# Altium schematic sheet E16-02-002.SchDoc(E16-02-002)
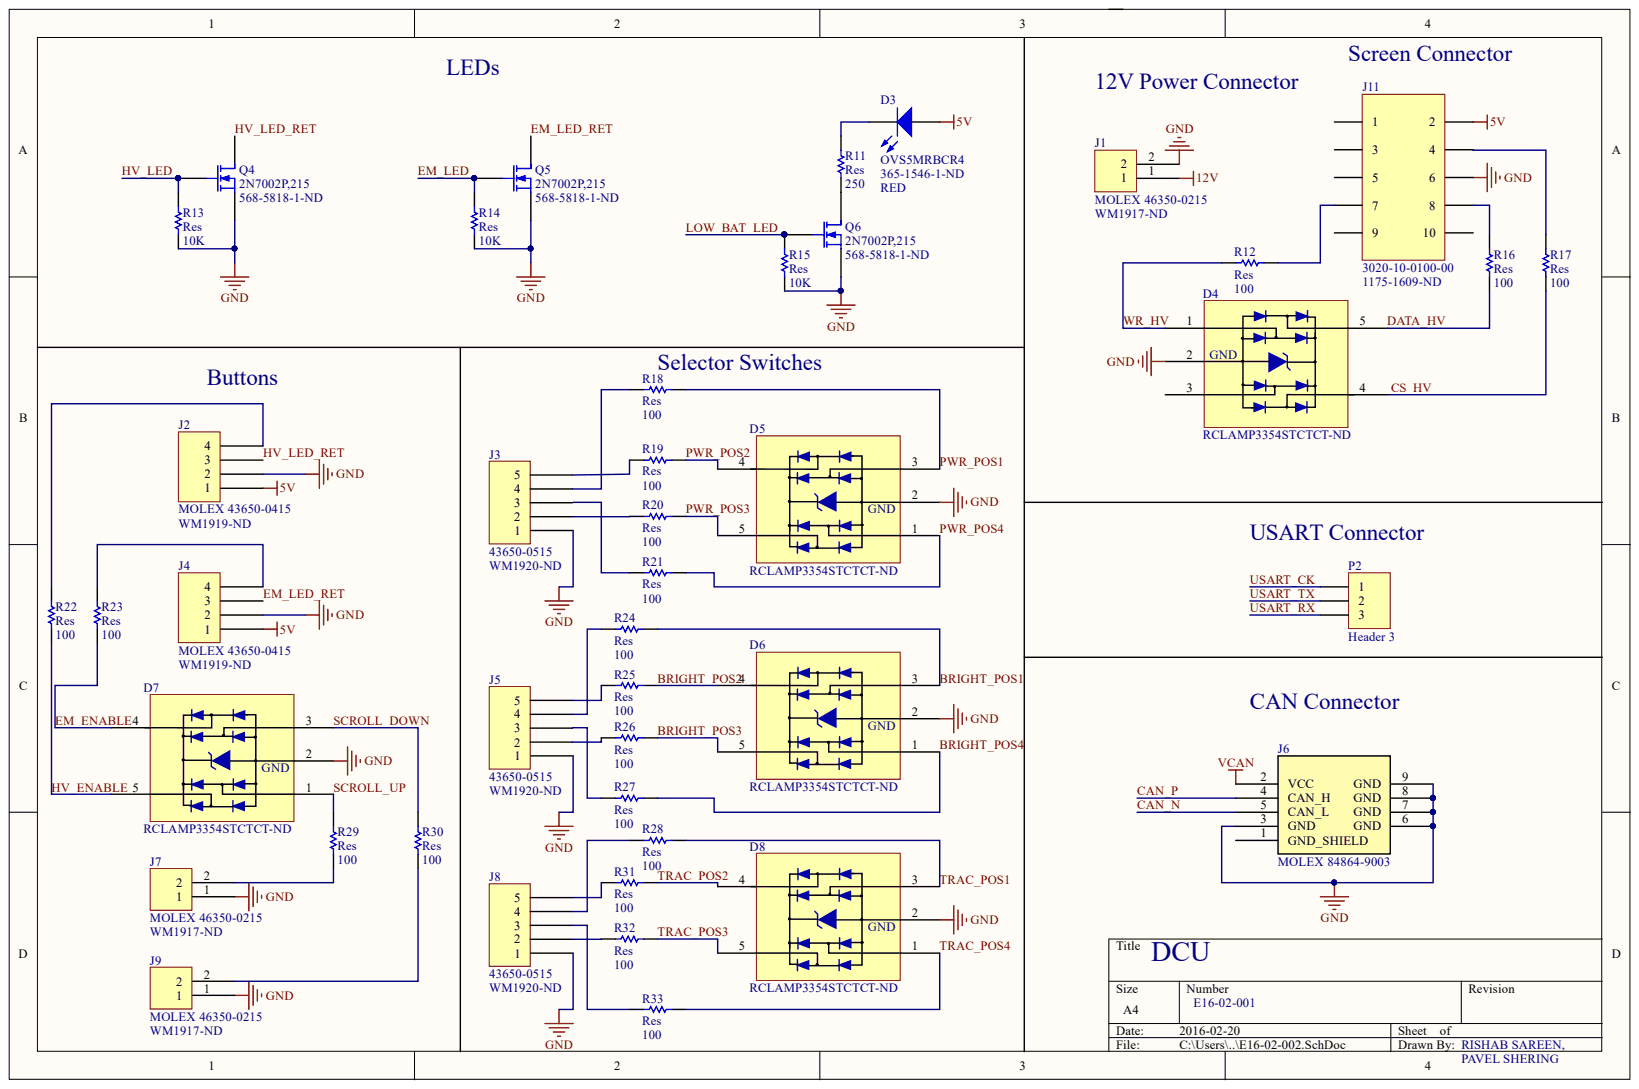
[diagram p0: E16-02-002.SchDoc]

## Parts
D3  OVS5MRBCR4  Supplier Part Number 1: 365-1546-1-ND  [OVS5MRBCR4]
D4  RCLAMP3354S.TCT  Supplier Part Number 1: RCLAMP3354STCTCT-ND  [SOT95P280X145-5N]
D5  RCLAMP3354S.TCT  Supplier Part Number 1: RCLAMP3354STCTCT-ND  [SOT95P280X145-5N]
D6  RCLAMP3354S.TCT  Supplier Part Number 1: RCLAMP3354STCTCT-ND  [SOT95P280X145-5N]
D7  RCLAMP3354S.TCT  Supplier Part Number 1: RCLAMP3354STCTCT-ND  [SOT95P280X145-5N]
D8  RCLAMP3354S.TCT  Supplier Part Number 1: RCLAMP3354STCTCT-ND  [SOT95P280X145-5N]
J1  MOLEX 46350-0215  Supplier Part Number 1: WM1917-ND  [MOLEX 43650-0215]
J2  MOLEX 43650-0415  Supplier Part Number 1: WM1919-ND  [MOLEX 43650-0415]
J3  43650-0515  Supplier Part Number 1: WM1920-ND  [MOLEX 43650-0515]
J4  MOLEX 43650-0415  Supplier Part Number 1: WM1919-ND  [MOLEX 43650-0415]
J5  43650-0515  Supplier Part Number 1: WM1920-ND  [MOLEX 43650-0515]
J6  MOLEX 84864-9003  [MOLEX 84864-9003]
J7  MOLEX 46350-0215  Supplier Part Number 1: WM1917-ND  [MOLEX 43650-0215]
J8  43650-0515  Supplier Part Number 1: WM1920-ND  [MOLEX 43650-0515]
J9  MOLEX 46350-0215  Supplier Part Number 1: WM1917-ND  [MOLEX 43650-0215]
J11  3020-10-0100-00  [IDC 10 Pos]
P2  Header 3  [HDR1X3]
Q4  2N7002P,215  Supplier Part Number 1: 568-5818-1-ND  [SOT23-3]
Q5  2N7002P,215  Supplier Part Number 1: 568-5818-1-ND  [SOT23-3]
Q6  2N7002P,215  Supplier Part Number 1: 568-5818-1-ND  [SOT23-3]
R11  Res  value 250  [3216[1206]]
R12  Res  value 100  [3216[1206]]
R13  Res  value 10K  [3216[1206]]
R14  Res  value 10K  [3216[1206]]
R15  Res  value 10K  [3216[1206]]
R16  Res  value 100  [3216[1206]]
R17  Res  value 100  [3216[1206]]
R18  Res  value 100  [3216[1206]]
R19  Res  [3216[1206]]
R20  Res  value 100  [3216[1206]]
R21  Res  value 100  [3216[1206]]
R22  Res  value 100  [3216[1206]]
R23  Res  value 100  [3216[1206]]
R24  Res  value 100  [3216[1206]]
R25  Res  value 100  [3216[1206]]
R26  Res  value 100  [3216[1206]]
R27  Res  value 100  [3216[1206]]
R28  Res  value 100  [3216[1206]]
R29  Res  value 100  [3216[1206]]
R30  Res  value 100  [3216[1206]]
R31  Res  value 100  [3216[1206]]
R32  Res  value 100  [3216[1206]]
R33  Res  value 100  [3216[1206]]

## Nets
5V: D3.1 J2.1 J4.1 J11.2
12V: J1.1
GND: D4.2 D5.2 D6.2 D7.2 D8.2 J1.2 J2.2 J3.1 J4.2 J5.1 J6.3(GND) J6.6(GND) J6.7(GND) J6.8(GND) J6.9(GND) J7.1 J8.1 J9.1 J11.6 Q4.2 Q5.2 Q6.2 R13.1 R14.1 R15.1
VCAN: J6.2(VCC)
BRIGHT_POS1: D6.3 R24.1
BRIGHT_POS2: D6.4 R25.2
BRIGHT_POS3: D6.5 R26.2
BRIGHT_POS4: D6.1 R27.1
CAN_N: J6.5(CAN_L)
CAN_P: J6.4(CAN_H)
CS_HV: D4.4 R17.1
DATA_HV: D4.5 R16.1
EM_ENABLE: D7.4 R23.1
EM_LED: Q5.1 R14.2
EM_LED_RET: J4.3 Q5.3
HV_ENABLE: D7.5 R22.1
HV_LED: Q4.1 R13.2
HV_LED_RET: J2.3 Q4.3
LOW_BAT_LED: Q6.1 R15.2
PWR_POS1: D5.3 R18.1
PWR_POS2: D5.4 R19.1
PWR_POS3: D5.5 R20.2
PWR_POS4: D5.1 R21.1
SCROLL_DOWN: D7.3 R30.2
SCROLL_UP: D7.1 R29.1
TRAC_POS1: D8.3 R28.1
TRAC_POS2: D8.4 R31.2
TRAC_POS3: D8.5 R32.2
TRAC_POS4: D8.1 R33.1
USART_CK: P2.1
USART_RX: P2.3
USART_TX: P2.2
WR_HV: D4.1 R12.1
NetD3_2: D3.2 R11.2
NetJ2_4: J2.4 R22.2
NetJ3_2: J3.2 R20.1
NetJ3_4: J3.4 R18.2
NetJ3_5: J3.5 R19.2
NetJ4_4: J4.4 R23.2
NetJ5_2: J5.2 R26.1
NetJ5_3: J5.3 R27.2
NetJ5_4: J5.4 R24.2
NetJ5_5: J5.5 R25.1
NetJ7_2: J7.2 R29.2
NetJ8_2: J8.2 R32.1
NetJ8_3: J8.3 R33.2
NetJ8_4: J8.4 R28.2
NetJ8_5: J8.5 R31.1
NetJ9_2: J9.2 R30.1
NetJ11_4: J11.4 R17.2
NetJ11_7: J11.7 R12.2
NetJ11_8: J11.8 R16.2
NetQ6_3: Q6.3 R11.1

Not connected: D4 3; J3 3; J6 1(GND_SHIELD); J11 1 3 5 9 10; R21 2

## Library data of the parts
D3 = OVS5MRBCR4: Typical RED LED; Colour: RED; Component Kind: Standard; LatestRevisionDate: 17-Jul-2002; LatestRevisionNote: Re-released for DXP Platform.; Library Name: LEDs.IntLib; PackageDocument: Feb-2002; PackageReference: 3.2X1.6X1.1; Published: 8-Jun-2000; Supplier 1: Digi-Key; Publisher: Altium Limited
D4-D8 = RCLAMP3354S.TCT: TVS DIODE 3.3VWM 16VC; Component Kind: Standard; Library Name: Diodes.IntLib; Supplier 1: Digi-Key
J1 J7 J9 = MOLEX 46350-0215: Molex 2 Pin Male Header, Vertical, Through Hole; Component Kind: Standard; Library Name: Connectors and Headers.IntLib; Supplier 1: Digi-Key
J2 J4 = MOLEX 43650-0415: Molex 4 Pin Male Header, Vertical, Through Hole; Component Kind: Standard; Library Name: Connectors and Headers.IntLib; Supplier 1: Digi-Key
J3 J5 J8 = MOLEX 43650-0515: Molex 5 Pin Male Header, Vertical, Through Hole; Component Kind: Standard; Library Name: Connectors and Headers.IntLib; Supplier 1: Digi-Key
J6 = MOLEX 84864-9003: CAN Micro-Change M12, Right Angle, Male; Component Kind: Standard; Library Name: Connectors and Headers.IntLib
J11 = IDC_10Pos: Component Kind: Standard; Library Name: Connectors and Headers.IntLib; Supplier 1: Digi-Key; Supplier Part Number 1: 1175-1609-ND
P2 = Header 3: Header, 3-Pin; Component Kind: Standard; LatestRevisionDate: 17-Jul-2002; LatestRevisionNote: Re-released for DXP Platform.; Library Name: Connectors and Headers.IntLib; Publisher: Altium Limited
Q4-Q6 = 2N7002P,215: N-Channel Enhancement Mode Vertical DMOS FET; Component Kind: Standard; Library Name: Transistors.IntLib; PackageDescription: SOT-23; 3 Leads; Body 3.1 x 2.5 mm, inc. leads (LxW max), Alternate Lead Configuration; PackageReference: SOT23; Supplier 1: Digi-Key
R11 R13-R18 R20-R33 = Res: Surface Mount Resistor; Component Kind: Standard; LatestRevisionDate: 17-Jul-2002; LatestRevisionNote: Re-released for DXP Platform.; Library Name: Resistors.IntLib; PackageReference: AXIAL-0.5; Published: 8-Jun-2000; Publisher: Altium Limited
R12 R19 = Res: Surface Mount Resistor; Value: 100; Component Kind: Standard; LatestRevisionDate: 17-Jul-2002; LatestRevisionNote: Re-released for DXP Platform.; Library Name: Resistors.IntLib; PackageReference: AXIAL-0.5; Published: 8-Jun-2000; Publisher: Altium Limited
